# Altium schematic sheet linkit-v4.SchDoc(U_linkit-v4)
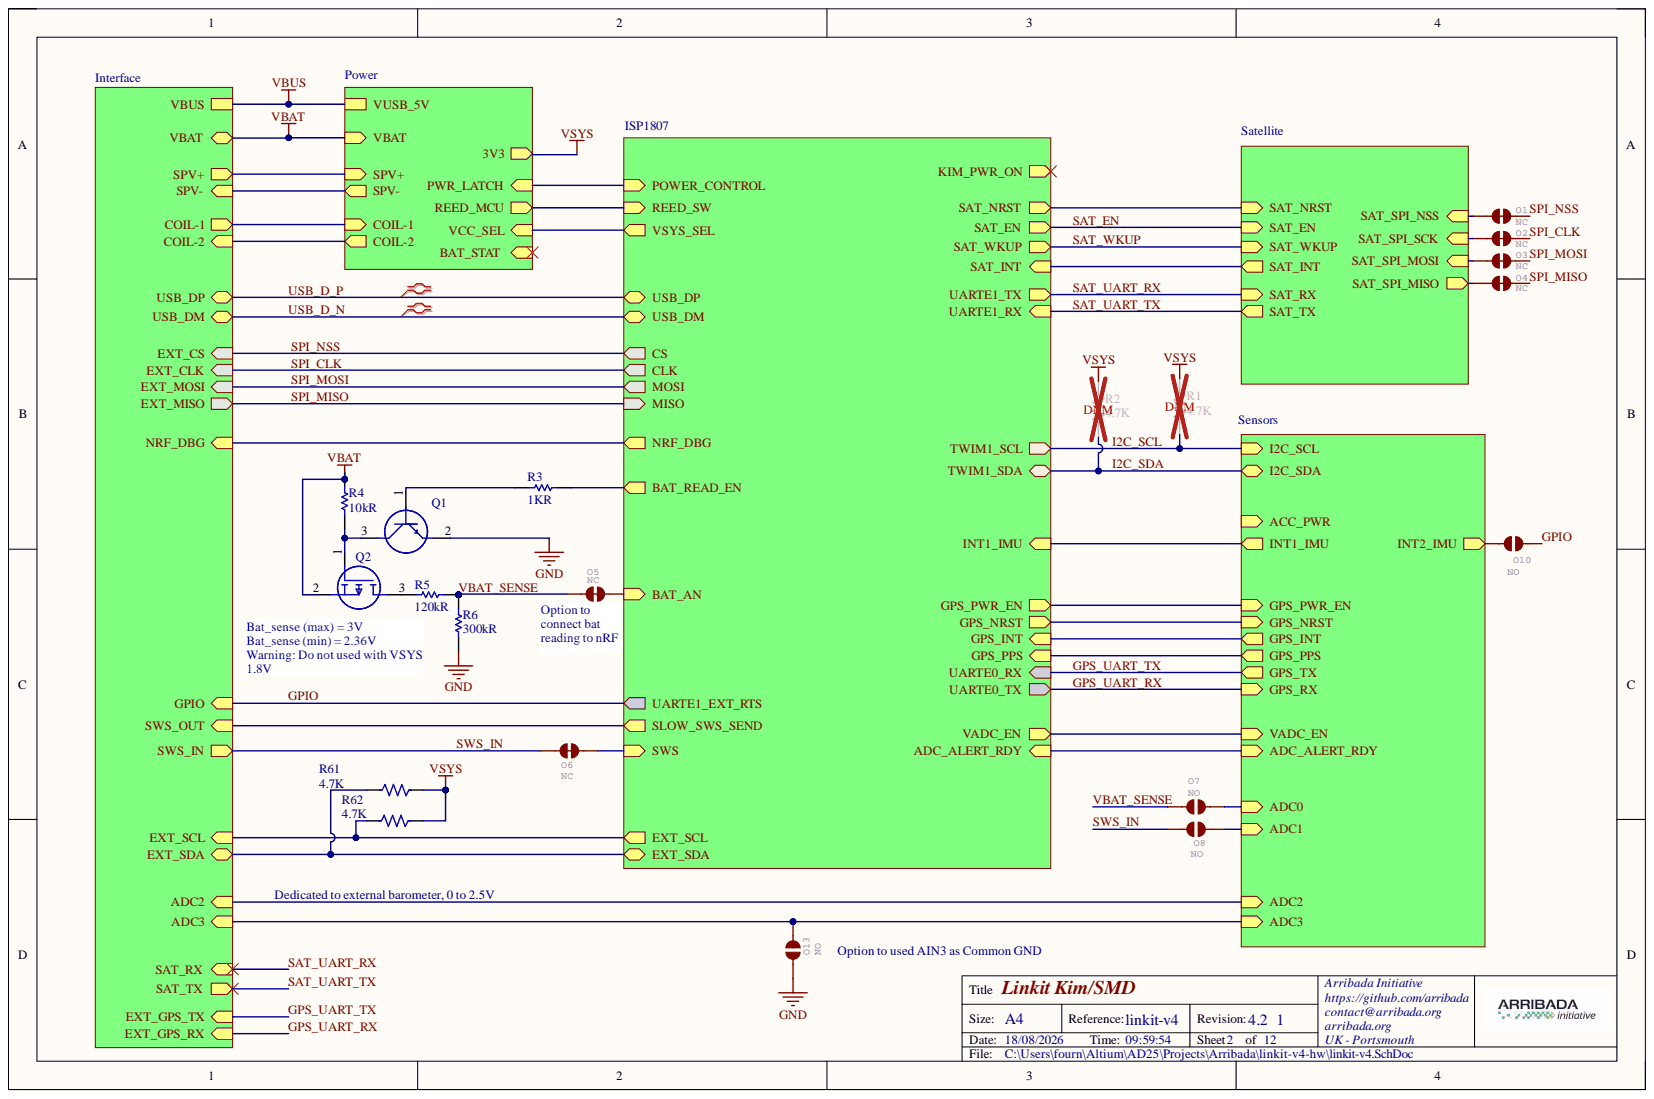
[diagram p0: linkit-v4.SchDoc]

## Parts
O1  NC  [SMT-JUMPER_2_NC_TRACE_SILK]
O2  NC  [SMT-JUMPER_2_NC_TRACE_SILK]
O3  NC  [SMT-JUMPER_2_NC_TRACE_SILK]
O4  NC  [SMT-JUMPER_2_NC_TRACE_SILK]
O5  NC  [SMT-JUMPER_2_NC_TRACE_SILK]
O6  NC  [SMT-JUMPER_2_NC_TRACE_SILK]
O7  NO  [SMT-JUMPER_2_NO_TRACE_SILK]
O8  NO  [SMT-JUMPER_2_NO_TRACE_SILK]
O10  NO  [SMT-JUMPER_2_NO_TRACE_SILK]
O13  NO  [SMT-JUMPER_2_NO_TRACE_SILK]
Q1  MMBT3904LT1G  [SOT96P237X111-3N]  (value not drawn)
Q2  DMP2305U-7  [SOT96P240X115-3N]  (value not drawn)
R1  value 4.7K  [RES0201L]  (hidden comment: 4.7K 0201 1% 100PPM)
R2  value 4.7K  [RES0201L]  (hidden comment: 4.7K 0201 1% 100PPM)
R3  1kR  [RESC0603X28N]
R4  value 10kR  Resistance: 10kR  [RESC1005X40N]  (hidden comment: CRCW040210K0JNEDC)
R5  value 120kR  [ERA3AEB101V]  (hidden comment: ERA-3AEB124V)
R6  value 300kR  [ERA3AEB101V]  (hidden comment: ERA-3AEB304V)
R61  value 4.7K  [RES0201L]  (hidden comment: 4.7K 0201 1% 100PPM)
R62  value 4.7K  [RES0201L]  (hidden comment: 4.7K 0201 1% 100PPM)

## Nets
GND: O13.1 Q1.2 R6.1
VBAT: Q2.2 R4.1
VSYS: R1.2 R2.2 R61.2 R62.1
GPIO: O10.1
I2C_SCL: R1.1
I2C_SDA: R2.1
SPI_CLK: O2.2
SPI_MISO: O4.2
SPI_MOSI: O3.2
SPI_NSS: O1.2
SWS_IN: O6.1 O8.1
VBAT_SENSE: O5.1 O7.1 R5.2 R6.2
NetO1_1: O1.1
NetO2_1: O2.1
NetO3_1: O3.1
NetO4_1: O4.1
NetO5_2: O5.2
NetO6_2: O6.2
NetO7_2: O7.2
NetO8_2: O8.2
NetO10_2: O10.2
NetO13_2: O13.2
NetQ1_1: Q1.1 R3.1
NetQ1_3: Q1.3 Q2.1 R4.2
NetQ2_3: Q2.3 R5.1
NetR3_2: R3.2
NetR61_1: R61.1
NetR62_2: R62.2
ACC_PWR:
ADC2:
ADC_ALERT_RDY:
BAT_STAT:
COIL-1:
COIL-2:
GPS_INT:
GPS_NRST:
GPS_PPS:
GPS_PWR_EN:
GPS_UART_RX:
GPS_UART_TX:
INT1_IMU:
KIM_PWR_ON:
NRF_DBG:
POWER_CONTROL:
REED_MCU:
SAT_EN:
SAT_INT:
SAT_NRST:
SAT_UART_RX:
SAT_UART_TX:
SAT_WKUP:
SLOW_SWS_SEND:
SPV+:
SPV-:
USB_D_N:
USB_D_P:
VADC_EN:
VBUS:
VCC_SEL:

## Library data of the parts
O1-O6 = JUMPER-SMT_2_NC_TRACE_SILK: Normally closed trace jumper    This jumper has a trace between two pads so it's normally closed (NC). Use a razor knife to open the connection. For best results follow the IPC guidelines for cutting traces: Cutout at least 0.063 mm (0.005 in).  Remove all loose material to clean up the cut area.  Seal the cut with an approved epoxy.       Reapply solder to reclose the connection.; DeviceName: _SILK; GateName_1: G$1; SymbolName_1: SMT-JUMPER_2_NC_TRACE; Component Kind: Net Tie; DeviceSetName: JUMPER-SMT_2_NC_TRACE; Library Name: stm32wl-dev.SchLib; LibraryName: SparkFun-Jumpers
O7 O8 O10 O13 = JUMPER-SMT_2_NO_TRACE_SILK_1: Normally opened trace jumper      This jumper has no trace between two pads so it's normally closed (NO).; DeviceName: _SILK; DeviceSetName: JUMPER-SMT_2_NC_TRACE; GateName_1: G$1; SymbolName_1: SMT-JUMPER_2_NC_TRACE; Component Kind: Net Tie; Library Name: stm32wl-dev.SchLib; LibraryName: SparkFun-Jumpers
Q1 = MMBT3904LT1G: Bipolar Transistors - BJT NPN GENERAL PURPOSE; Manufacturer_Name: onsemi; Arrow Part Number: MMBT3904LT1G; Arrow Price/Stock: https://www.arrow.com/en/products/mmbt3904lt1g/on-semiconductor?utm_currency=USD&region=europe; Component Kind: Standard; Datasheet Link: https://www.onsemi.com/pub/Collateral/MMBT3904LT1-D.PDF; Height: 1.11mm; Library Name: stm32wl-dev.SchLib; Manufacturer_Part_Number: MMBT3904LT1G; Mouser Part Number: 863-MMBT3904LT1G; Mouser Price/Stock: https://www.mouser.co.uk/ProductDetail/onsemi/MMBT3904LT1G?qs=HVbQlW5zcXUYZ2Fy0YF3FQ%3D%3D
Q2 = DMP2305U-7: MOSFET P-CHANNEL ENHANCEMENT MODE; Manufacturer_Name: Diodes Incorporated; Arrow Part Number: DMP2305U-7; Arrow Price/Stock: https://www.arrow.com/en/products/dmp2305u-7/diodes-incorporated?utm_currency=USD&region=nac; Component Kind: Standard; Datasheet Link: https://www.diodes.com//assets/Datasheets/DMP2305U.pdf; Height: 1.15mm; Library Name: stm32wl-dev.SchLib; Manufacturer_Part_Number: DMP2305U-7; Mouser Part Number: 621-DMP2305U-7; Mouser Price/Stock: https://www.mouser.co.uk/ProductDetail/Diodes-Incorporated/DMP2305U-7?qs=oUsD4qhOtFyFQmlG8wLVjw%3D%3D
R1 R2 R61 R62 = RES 4.7K 0201 1% 100PPM: PPM: 100; Component Kind: Standard; Description: RES 4.7K 0201 1% 100PPM; Library Name: Resistors.SchLib; Size: 0201; Supplier 1: Mouser; Supplier 2: Digi-Key; Supplier Part Number 1: 71-CRCW02014K70FKED; Supplier Part Number 2: 541-4.70KAABCT-ND; Tolerance: 1%
R3 = CRCW02011K00FNED: Thick Film Resistors - SMD 1/20watt 1Kohms 1% 200ppm; Manufacturer_Name: Vishay; Arrow Part Number: CRCW02011K00FNED; Arrow Price/Stock: https://www.arrow.com/en/products/crcw02011k00fned/vishay?region=nac; Component Kind: Standard; Datasheet Link: http://www.vishay.com/docs/20035/dcrcwe3.pdf; Height: 0.28mm; Library Name: stm32wl-dev.SchLib; Manufacturer_Part_Number: CRCW02011K00FNED; Mouser Part Number: 71-CRCW02011K00FNED; Mouser Price/Stock: https://www.mouser.co.uk/ProductDetail/Vishay-Dale/CRCW02011K00FNED?qs=IQLUlsvFoXtTp7ngtIXo7A%3D%3D; Value: 1KR
R4 = CRCW040210K0JNEDC: Thick Film Resistors - SMD 1/16watt 10Kohms 5% Commercial Use; Manufacturer: Vishay Semiconductors; Power Rating: 63mW; Voltage Rating: 50V; Case/Package: 343Case; Category: Chip SMD Resistors; Component Kind: Standard; ComponentLink1Description: http://www.vishay.com/docs/28773/crcwce3.pdf?src-supplier=Digikey; ComponentLink1URL: http://www.vishay.com/docs/28773/crcwce3.pdf?src-supplier=Digikey; ComponentLink2Description: https://datasheet.octopart.com/CRCW040210K0JNEDC-Vishay-datasheet-100063072.pdf?src-supplier=Future+Electronics; ComponentLink2URL: https://datasheet.octopart.com/CRCW040210K0JNEDC-Vishay-datasheet-100063072.pdf?src-supplier=Future+Electronics; Height: 350um; Lead Free: 5697LeadFree; Library Name: stm32wl-dev.SchLib; Manufacturer Part Number: CRCW040210K0JNEDC; Pricing 1: 1=0,1, 10=0,013, 50=0,013, 100=0,007, 1000=0,005, 10000=0,004 (USD); RoHS: Yes; Stock 1: 229087; Supplier 1: Mouser; Supplier Part Number 1: 71-CRCW040210K0JNEDC; Tolerance: 5%
R5 = ERA-3AEB124V: Thin Film Resistors - SMD 0603 120Kohm 1/10W AEC-Q200; Manufacturer_Name: Panasonic; Arrow Part Number: ERA-3AEB124V; Arrow Price/Stock: https://www.arrow.com/en/products/era3aeb124v/panasonic?utm_currency=USD&region=nac; Component Kind: Standard; Datasheet Link: https://industrial.panasonic.com/cdbs/www-data/pdf/RDM0000/AOA0000C307.pdf; Height: 0.55mm; Library Name: stm32wl-dev.SchLib; Manufacturer_Part_Number: ERA-3AEB124V; Mouser Part Number: 667-ERA-3AEB124V; Mouser Price/Stock: https://www.mouser.co.uk/ProductDetail/Panasonic/ERA-3AEB124V?qs=nho8PGNfA6pExpKvM%2FJG7g%3D%3D
R6 = ERA-3AEB304V: Thin Film Resistors - SMD 0603 1/10W 300Kohms; Manufacturer_Name: Panasonic; Arrow Part Number: ERA-3AEB304V; Arrow Price/Stock: https://www.arrow.com/en/products/era-3aeb304v/panasonic; Component Kind: Standard; Datasheet Link: https://industrial.panasonic.com/cdbs/www-data/pdf/RDM0000/AOA0000C307.pdf; Height: 0.55mm; Library Name: stm32wl-dev.SchLib; Manufacturer_Part_Number: ERA-3AEB304V; Mouser Part Number: 667-ERA-3AEB304V; Mouser Price/Stock: https://www.mouser.co.uk/ProductDetail/Panasonic/ERA-3AEB304V?qs=bHJq1HQHzUhRm5RFyWc5EQ%3D%3D
